# Altium schematic sheet BUCK_CONVERTERS.SchDoc(BUCK_CONVERTERS)
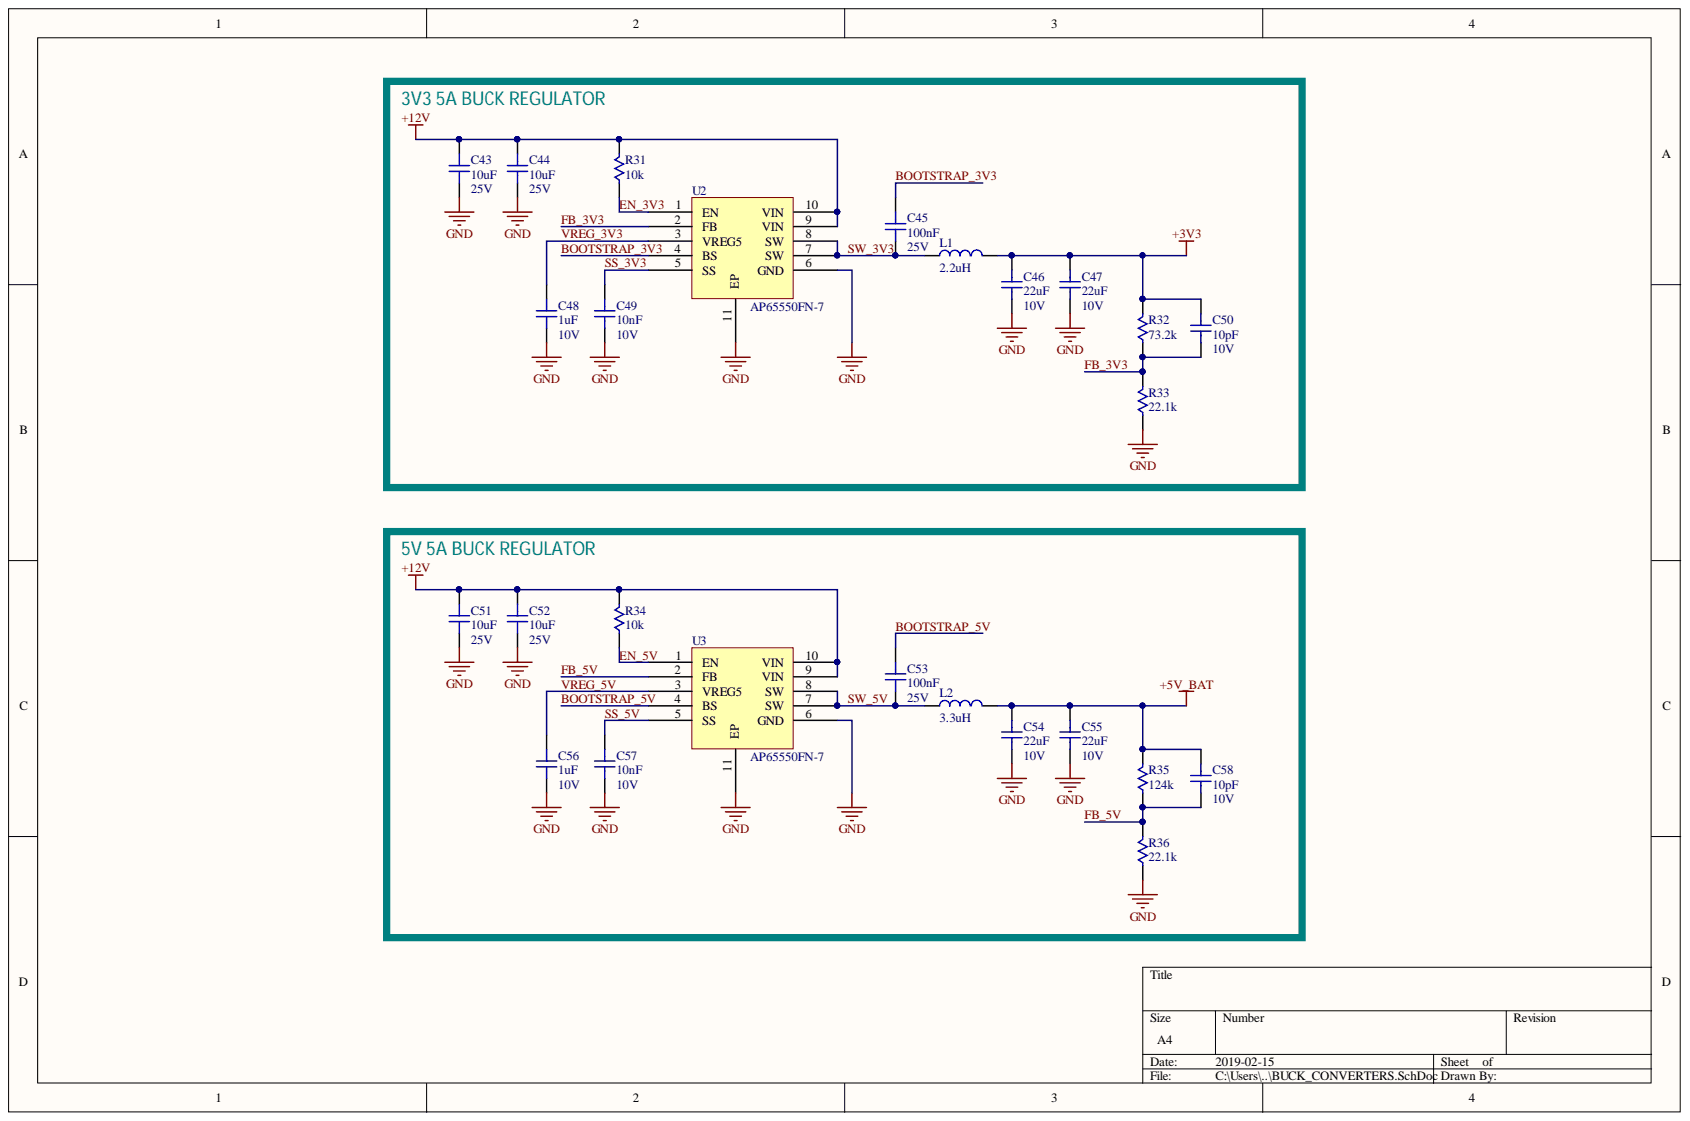
[diagram p0: BUCK_CONVERTERS.SchDoc]

## Parts
C43  10uF  Voltage Rating (DC): 25V  [R0805-3D]
C44  10uF  Voltage Rating (DC): 25V  [R0805-3D]
C45  100nF  Voltage Rating (DC): 25V  [C0402]
C46  22uF  Voltage Rating (DC): 10V  [R0805-3D]
C47  22uF  Voltage Rating (DC): 10V  [R0805-3D]
C48  1uF  Voltage Rating (DC): 10V  [C0402]
C49  10nF  Voltage Rating (DC): 10V  [C0402]
C50  10pF  Voltage Rating: 10V  [C0402]
C51  10uF  Voltage Rating (DC): 25V  [R0805-3D]
C52  10uF  Voltage Rating (DC): 25V  [R0805-3D]
C53  100nF  Voltage Rating (DC): 25V  [C0402]
C54  22uF  Voltage Rating (DC): 10V  [R0805-3D]
C55  22uF  Voltage Rating (DC): 10V  [R0805-3D]
C56  1uF  Voltage Rating (DC): 10V  [C0402]
C57  10nF  Voltage Rating (DC): 10V  [C0402]
C58  10pF  Voltage Rating: 10V  [C0402]
L1  2.2uH  [IND-6mm]
L2  3.3uH  [IND-6mm]
R31  10k  [R0402]
R32  73.2k  [R0402]
R33  22.1k  [R0402]
R34  10k  [R0402]
R35  124k  [R0402]
R36  22.1k  [R0402]
U2  AP65550FN-7  [DFN-10]
U3  AP65550FN-7  [DFN-10]

## Nets
+3V3: C46.2 C47.2 C50.2 L1.2 R32.2
+5V_BAT: C54.2 C55.2 C58.2 L2.2 R35.2
+12V: C43.2 C44.2 C51.2 C52.2 R31.2 R34.2 U2.9(VIN) U2.10(VIN) U3.9(VIN) U3.10(VIN)
GND: C43.1 C44.1 C46.1 C47.1 C48.1 C49.1 C51.1 C52.1 C54.1 C55.1 C56.1 C57.1 R33.1 R36.1 U2.6(GND) U2.11(EP) U3.6(GND) U3.11(EP)
BOOTSTRAP_3V3: C45.2 U2.4(BS)
BOOTSTRAP_5V: C53.2 U3.4(BS)
EN_3V3: R31.1 U2.1(EN)
EN_5V: R34.1 U3.1(EN)
FB_3V3: C50.1 R32.1 R33.2 U2.2(FB)
FB_5V: C58.1 R35.1 R36.2 U3.2(FB)
SS_3V3: C49.2 U2.5(SS)
SS_5V: C57.2 U3.5(SS)
SW_3V3: C45.1 L1.1 U2.7(SW) U2.8(SW)
SW_5V: C53.1 L2.1 U3.7(SW) U3.8(SW)
VREG_3V3: C48.2 U2.3(VREG5)
VREG_5V: C56.2 U3.3(VREG5)

## Library data of the parts
C43 C44 C51 C52 = TMK212BBJ106KG-T: CAP MLCC 10uF 25V X5R 0805; Manufacturer: Taiyo Yuden; Voltage: 16V; Tolerance: 10%; Dielectric: X7R; Width: 0.049inch; Voltage Rating: 25V; Thickness: 0.053inch; Termination: SMD/SMT; Supplier Part Number 1: 587-2985-1-ND; Supplier 1: Digi-Key; Stock 1: 3000; REACH SVHC: No SVHC; Pricing 1: 1=0.21, 10=0.144, 100=0.0729, 500=0.0499, 1000=0.0441 (USD); Packaging: Tape and Reel; Package Quantity: 1; Number of Pins: 2; Mount: Surface Mount; Min Operating Temperature: -55°C; Max Operating Temperature: 85°C; Manufacturer Part Number: TMK212BBJ106KG-T; Length: 0.079inch; Lead Free: Lead Free; Height: 1.25mm; Composition: Ceramic; ComponentLink2URL: http://www.farnell.com/datasheets/1481447.pdf; ComponentLink2Description: http://www.farnell.com/datasheets/1481447.pdf; ComponentLink1URL: https://www.yuden.co.jp/productdata/catalog/mlcc_all_e.pdf; ComponentLink1Description: https://www.yuden.co.jp/productdata/catalog/mlcc_all_e.pdf; Component Kind: Standard; Case Code (Metric): 2012; Case Code (Imperial): 0805; Case/Package: 0805; Capacitance: 10uF; Library Name: PHANTOM_LIBRARY.SchLib
C45 C53 = CC0402ZRY5V8BB104: CAP MLCC 0.1uF 25V Y5V 0402; Manufacturer: Yageo; Tolerance: 80%; Insulation Resistance: 10GR; Dissipation Factor: 12.5%; Width: 0.02inch; Weight: 2.3E-05oz; Voltage Rating: 25V; Thickness: 0.022inch; Termination: SMD/SMT; Supplier Part Number 1: 311-1375-1-ND; Supplier 1: Digi-Key; Stock 1: 100000; REACH SVHC: Unknown; Radiation Hardening: No; Pricing 1: 1=0.1, 10=0.031, 100=0.0136, 500=0.0097, 1000=0.0077, 2500=0.007, 5000=0.0064 (USD); Packaging: Tape and Reel; Package Quantity: 1; Number of Pins: 2; Mount: Surface Mount; Min Operating Temperature: -30°C; Max Operating Temperature: 85°C; Manufacturer Part Number: CC0402ZRY5V8BB104; Length: 1mm; Lead Free: Lead Free; Height: 0.5mm; Halogen Free: Halogen Free; Dielectric: Y5V; Depth: 0.5mm; Composition: Ceramic; ComponentLink3URL: http://www.yageo.com/documents/recent/UPY-GPHC_Y5V_6.3V-to-50V_5.pdf; ComponentLink3Description: http://www.yageo.com/documents/recent/UPY-GPHC_Y5V_6.3V-to-50V_5.pdf; ComponentLink2URL: http://www.farnell.com/datasheets/1132289.pdf; ComponentLink2Description: http://www.farnell.com/datasheets/1132289.pdf; ComponentLink1URL: http://www.yageo.com/documents/recent/UPY-GPHC_Y5V_6.3V-to-50V_8.pdf; ComponentLink1Description: http://www.yageo.com/documents/recent/UPY-GPHC_Y5V_6.3V-to-50V_8.pdf; Component Kind: Standard; Case Code (Metric): 1005; Case Code (Imperial): 0402; Case/Package: 0402; Capacitance: 100nF; Library Name: PHANTOM_LIBRARY.SchLib
C46 C47 C54 C55 = GRM21BR61A226ME51L: CAP MLCC 22uF 10V 0805 X5R 20% SMD 0805 85C Embossed T/R; Manufacturer: Murata; Width: 0.049inch; Weight: 0.000335oz; Voltage Rating: 10V; Tolerance: 20%; Thickness: 0.055inch; Termination: SMD/SMT; Supplier Part Number 1: 490-10511-1-ND; Supplier 1: Digi-Key; Stock 1: 30000; REACH SVHC: No SVHC; Radiation Hardening: No; Pricing 1: 1=0.35, 10=0.239, 100=0.1353, 500=0.0955, 1000=0.0836 (USD); Packaging: Tape and Reel; Package Quantity: 3000; Mount: Surface Mount; Min Operating Temperature: -55°C; Max Operating Temperature: 85°C; Manufacturer Part Number: GRM21BR61A226ME51L; Length: 2mm; Lead Free: Lead Free; Height: 1.25mm; Dielectric: X5R; Depth: 1.25mm; Component Kind: Standard; Case Code (Metric): 2012; Case Code (Imperial): 0805; Case/Package: 0805; Capacitance: 22uF; Library Name: PHANTOM_LIBRARY.SchLib
C48 C56 = CL05A105MP5NNNC: CAP MLCC 1uF 10V 0402 X5R 20% SMD 0402 85C Paper T/R; Manufacturer: Samsung; Width: 0.02inch; Voltage Rating: 10V; Tolerance: 20%; Thickness: 0.022inch; Supplier Part Number 1: 1276-1448-1-ND; Supplier 1: Digi-Key; Stock 1: 100000; Radiation Hardening: No; Pricing 1: 1=0.1, 10=0.031, 100=0.014, 500=0.01, 1000=0.0079, 2500=0.0072, 5000=0.0066 (USD); Packaging: Tape and Reel; Package Quantity: 1; Mount: Surface Mount; Min Operating Temperature: -55°C; Max Operating Temperature: 85°C; Manufacturer Part Number: CL05A105MP5NNNC; Length: 1mm; Lead Free: Lead Free; Height: 0.5mm; Dielectric: X5R; Depth: 0.5mm; ComponentLink1URL: https://media.digikey.com/pdf/Data%20Sheets/Samsung%20PDFs/CL_Series_MLCC_ds.pdf; ComponentLink1Description: https://media.digikey.com/pdf/Data%20Sheets/Samsung%20PDFs/CL_Series_MLCC_ds.pdf; Component Kind: Standard; Case Code (Metric): 1005; Case Code (Imperial): 0402; Case/Package: 0402; Capacitance: 1uF; Library Name: PHANTOM_LIBRARY.SchLib
C49 C57 = GRM155R61A103KA01D: CAP MLCC 10nF 10V 0402 5R 10% SMD 0402 85C T/R; Manufacturer: Murata; Dielectric: X5R; Width: 0.02inch; Voltage Rating: 10V; Tolerance: 10%; Thickness: 0.022inch; Termination: SMD/SMT; Supplier Part Number 1: 490-6296-1-ND; Supplier 1: Digi-Key; Stock 1: 100000; Radiation Hardening: No; Pricing 1: 1=0.1, 10=0.029, 100=0.013, 500=0.0093, 1000=0.0073, 2500=0.0066, 5000=0.0061 (USD); Packaging: Tape and Reel; Package Quantity: 10000; Mount: Surface Mount; Min Operating Temperature: -55°C; Max Operating Temperature: 85°C; Manufacturer Part Number: GRM155R61A103KA01D; Length: 1mm; Lead Free: Lead Free; Height: 0.5mm; Depth: 0.5mm; ComponentLink3URL: http://psearch.murata.com/capacitor/product/GRM155R61A103KA01%23.pdf; ComponentLink3Description: http://psearch.murata.com/capacitor/product/GRM155R61A103KA01%23.pdf; ComponentLink2URL: https://www.verical.com/server-webapp/html/getDatasheet?partID=330538; ComponentLink2Description: https://www.verical.com/server-webapp/html/getDatasheet?partID=330538; ComponentLink1URL: https://www.murata.com/~/media/webrenewal/support/library/catalog/products/capacitor/mlcc/c02e.ashx?la=en-us; ComponentLink1Description: https://www.murata.com/~/media/webrenewal/support/library/catalog/products/capacitor/mlcc/c02e.ashx?la=en-us; Component Kind: Standard; Case Code (Metric): 1005; Case Code (Imperial): 0402; Case/Package: 0402; Capacitance: 10nF; Library Name: PHANTOM_LIBRARY.SchLib
C50 C58 = 885012005007: CAP MLCC 10pF 10V 0402 C0G, NP0 0402 (1005 Metric) 0.039" L x 0.020" W (1.00mm x 0.50mm); Manufacturer: Wurth Electronics; Tolerance: 5%; Width: 0.02inch; Thickness: 0.022inch; Supplier Part Number 1: 732-7425-1-ND; Supplier 1: Digi-Key; Stock 1: 20214; Pricing 1: 1=0.1, 10=0.018, 100=0.0159, 500=0.0141 (USD); Packaging: Tape and Reel; Mount: Surface Mount; Min Operating Temperature: -55°C; Max Operating Temperature: 125°C; Manufacturer Part Number: 885012005007; Length: 0.039inch; Component Kind: Standard; Case Code (Metric): 1005; Case Code (Imperial): 0402; Case/Package: 0402; Capacitance: 10pF; Library Name: PHANTOM_LIBRARY.SchLib
L1 = 1255AY-2R2N=P3: IND 2.2uH 6.3A 19.2mOhm 30% 100KHz Ferrite  T/R; Manufacturer: Murata; Shielding: Shielded; Max DC Current: 4.7A; DC Resistance (DCR): 19.2mR; Width: 0.248inch; Tolerance: 30%; Test Frequency: 100kHz; Supplier Part Number 1: 490-10807-1-ND; Supplier 1: Digi-Key; Stock 1: 814; Pricing 1: 1=0.48, 10=0.423, 100=0.3019, 500=0.2536 (USD); Packaging: Tape and Reel; Mount: Surface Mount; Manufacturer Part Number: 1255AY-2R2N=P3; Length: 0.248inch; Inductance: 2.2uh; Height - Seated (Max): 0.177inch; Current Rating: 6.3A; Core Material: Ferrite; Composition: Wirewound; Component Kind: Standard; Case/Package: Nonstandard; Library Name: PHANTOM_LIBRARY.SchLib
L2 = 1264EY-3R3N=P3: IND 3.3µH 6.5A 25.2 mOhm Max Nonstandard; Manufacturer: Murata; Tolerance: 30%; Test Frequency: 100kHz; Shielding: Shielded; DC Resistance (DCR): 25.2mR; Core Material: Ferrite; Width: 0.248inch; Supplier Part Number 1: 490-10821-1-ND; Supplier 1: Digi-Key; Stock 1: 81; Pricing 1: 1=0.51, 10=0.443, 100=0.3163, 500=0.2657 (USD); Packaging: Tape and Reel; Mount: Surface Mount; Manufacturer Part Number: 1264EY-3R3N=P3; Length: 0.248inch; Inductance: 3.3uh; Height - Seated (Max): 0.198inch; Current Rating: 6.5A; Composition: Wirewound; Component Kind: Standard; Case/Package: Nonstandard; Library Name: PHANTOM_LIBRARY.SchLib
R31 R34 = RC0402JR-0710KL: RES SMD 10K OHM 5% 1/16W 0402; Manufacturer: Yageo; Voltage Rating: 50V; Temperature Coefficient: 100ppm/°C; Resistance: 10kR; Power Rating: 62.5mW; Max Power Dissipation: 63mW; Width: 0.02inch; Tolerance: 5%; Termination: SMD/SMT; Supplier Part Number 1: 311-10KJRCT-ND; Supplier 1: Digi-Key; Stock 1: 47303622; REACH SVHC: Unknown; Pricing 1: 1=0.1, 10=0.018, 100=0.0073, 1000=0.0033, 2500=0.0029, 5000=0.0024 (USD); Packaging: Tape and Reel; Package Quantity: 1; Number of Terminations: 2; Min Operating Temperature: -55°C; Military Standard: Not; Max Operating Temperature: 155°C; Manufacturer Part Number: RC0402JR-0710KL; Length: 1mm; Lead Free: Lead Free; Height: 0.016inch; Features: Moisture Resistant; Depth: 0.5mm; Composition: Thick Film; Component Kind: Standard; Case Code (Metric): 1005; Case Code (Imperial): 0402; Case/Package: 0402; Library Name: PHANTOM_LIBRARY.SchLib
R32 = RMCF0402FT73K2: RES SMD 73.2K Ohm 0402 1% 0.063W(1/16W) ±100ppm/C Molded SMD Automotive T/R; Manufacturer: Stackpole Electronics; Temperature Coefficient: 100ppm/°C; Resistance: 73.2kR; Max Power Dissipation: 63mW; Width: 0.02inch; Tolerance: 1%; Supplier Part Number 1: RMCF0402FT73K2CT-ND; Supplier 1: Digi-Key; Stock 1: 58296; Pricing 1: 1=0.1, 10=0.016, 100=0.0064, 1000=0.0029, 2500=0.0025, 5000=0.0021 (USD); Packaging: Tape and Reel; Number of Terminations: 2; Mount: Surface Mount; Min Operating Temperature: -55°C; Military Standard: Not; Max Operating Temperature: 155°C; Manufacturer Part Number: RMCF0402FT73K2; Length: 0.039inch; Lead Free: Lead Free; Height: 0.016inch; Features: Automotive AEC-Q200; Depth: 0.5mm; Contact Plating: Matte,Tin; Composition: Thick Film; Component Kind: Standard; Case Code (Metric): 1005; Case Code (Imperial): 0402; Case/Package: 0402; Library Name: PHANTOM_LIBRARY.SchLib
R33 R36 = RMCF0402FT22K1: RES SMD 22.1K 0402 Ohm 1% 1/16W ±100ppm/C Molded SMD SMD Paper T/R; Manufacturer: Stackpole Electronics; Temperature Coefficient: 100ppm/°C; Resistance: 22.1kR; Max Power Dissipation: 63mW; Width: 0.02inch; Tolerance: 1%; Supplier Part Number 1: RMCF0402FT22K1CT-ND; Supplier 1: Digi-Key; Stock 1: 115582; Radiation Hardening: No; Pricing 1: 1=0.1, 10=0.016, 100=0.0064, 1000=0.0029, 2500=0.0025, 5000=0.0021 (USD); Packaging: Tape and Reel; Number of Terminations: 2; Mount: Surface Mount; Min Operating Temperature: -55°C; Max Operating Temperature: 155°C; Manufacturer Part Number: RMCF0402FT22K1; Length: 0.039inch; Lead Free: Lead Free; Height: 0.016inch; Features: Automotive AEC-Q200; Contact Plating: Matte,Tin; Composition: Thick Film; Component Kind: Standard; Case Code (Metric): 1005; Case Code (Imperial): 0402; Case/Package: 0402; Library Name: PHANTOM_LIBRARY.SchLib
R35 = RMCF0402FT124K: RES SMD 124K 0402 1% 1/16W 100ppm/C Molded SMD Paper T/R; Manufacturer: Stackpole Electronics; Temperature Coefficient: 100ppm/°C; Resistance: 124kR; Max Power Dissipation: 63mW; Width: 0.02inch; Tolerance: 1%; Supplier Part Number 1: RMCF0402FT124KCT-ND; Supplier 1: Digi-Key; Stock 1: 25555; Pricing 1: 1=0.1, 10=0.016, 100=0.0064, 1000=0.0029, 2500=0.0025, 5000=0.0021 (USD); Packaging: Tape and Reel; Package Quantity: 10000; Number of Terminations: 2; Mount: Surface Mount; Min Operating Temperature: -55°C; Max Operating Temperature: 155°C; Manufacturer Part Number: RMCF0402FT124K; Length: 0.039inch; Lead Free: Lead Free; Height: 0.016inch; Features: Automotive AEC-Q200; Contact Plating: Matte,Tin; Composition: Thick Film; Component Kind: Standard; Case Code (Metric): 1005; Case Code (Imperial): 0402; Case/Package: 0402; Library Name: PHANTOM_LIBRARY.SchLib
U2 U3 = AP65550FN-7: IC BUCK SYNC 5A, 18V VIN MAX 650kHz COT Step-Down Conv; Manufacturer: Diodes; Synchronous Rectifier: Yes; Output Voltage: 6V; Output Type: Adjustable; Output Configuration: Positive; Operating Supply Current: 900uA; Number of Outputs: 1; Min Supply Voltage: 4.5V; Min Output Voltage: 760mV; Switching Frequency: 650kHz; Supplier Part Number 1: AP65550FN-7DICT-ND; Supplier 1: Digi-Key; Stock 1: 135; Pricing 1: 1=0.77, 10=0.68, 100=0.5212, 500=0.412, 1000=0.3296 (USD); Packaging: Tape and Reel; Package Quantity: 3000; Output Current: 5A; Mount: Surface Mount; Min Operating Temperature: -40°C; Min Input Voltage: 4.5V; Max Output Voltage: 6V; Max Operating Temperature: 85°C; Max Input Voltage: 18V; Manufacturer Part Number: AP65550FN-7; Lead Free: Lead Free; Component Kind: Standard; Library Name: PHANTOM_LIBRARY.SchLib
